# Altium schematic sheet LEDS_And_Buttons.SchDoc(LEDS_And_Buttons)
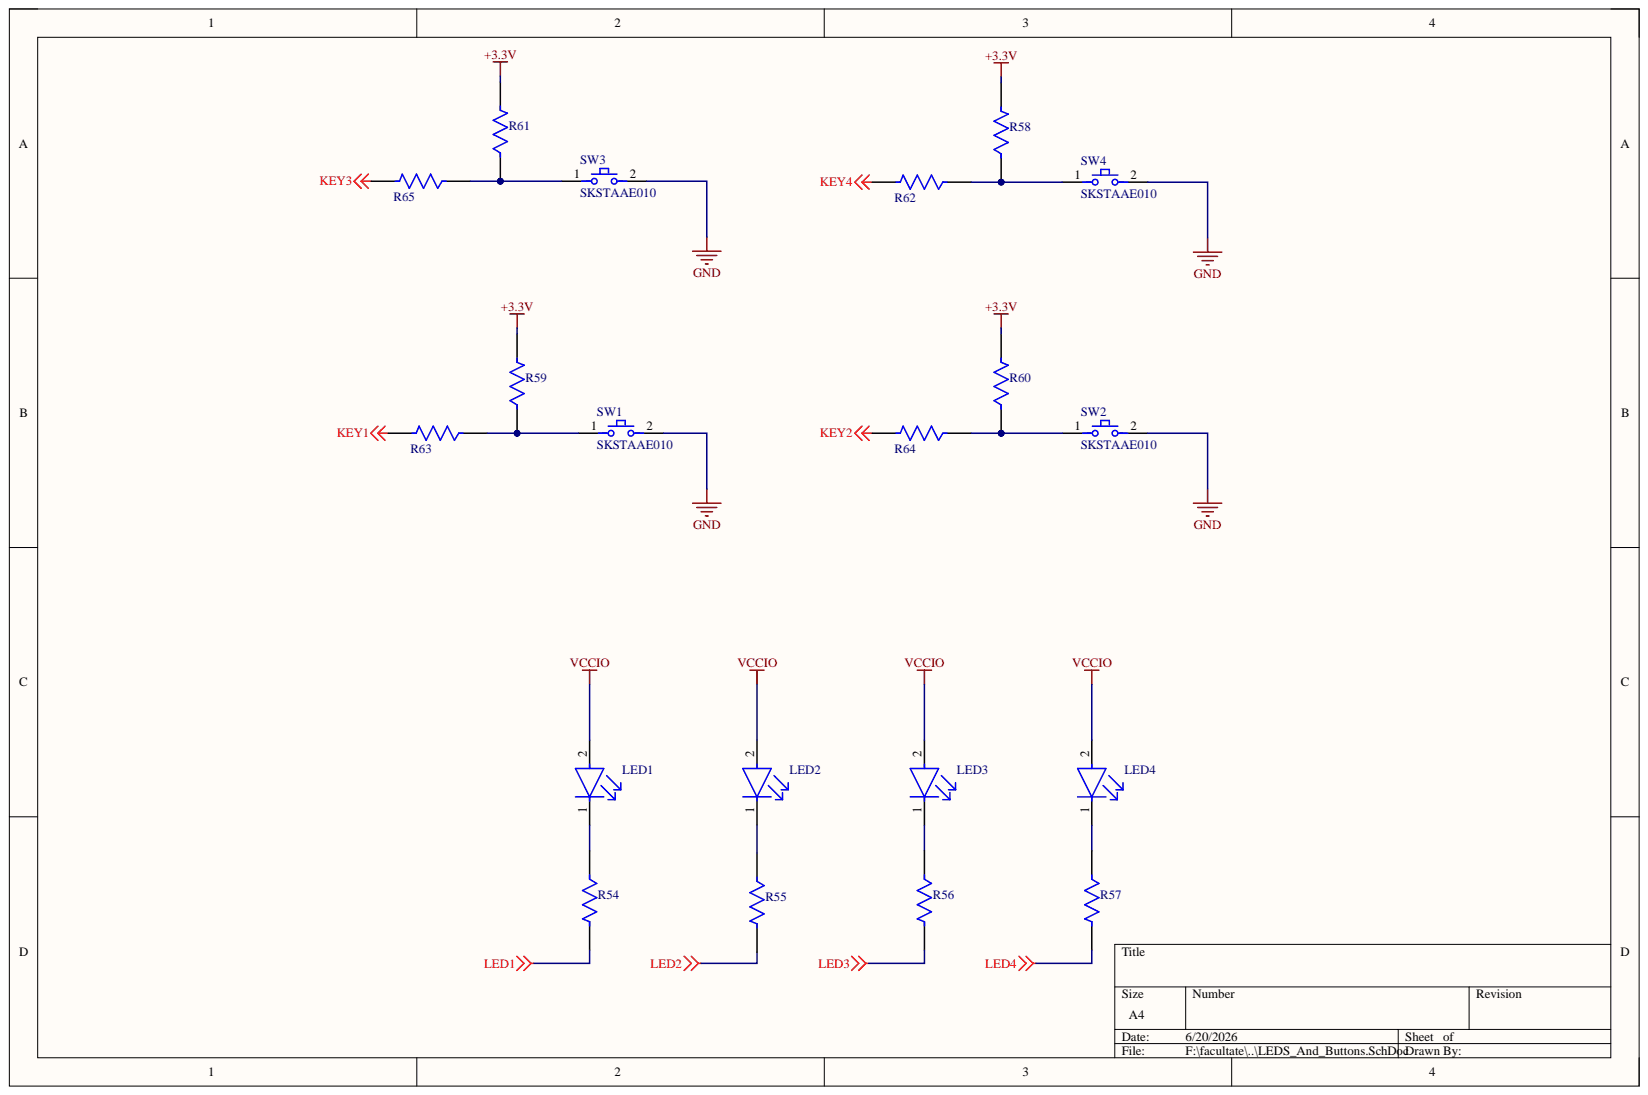
[diagram p0: LEDS_And_Buttons.SchDoc]

## Parts
LED1  LTST-C230EKT  [FP-LTST-C230EKT-MFG]  (value not drawn)
LED2  LTST-C230EKT  [FP-LTST-C230EKT-MFG]  (value not drawn)
LED3  LTST-C230EKT  [FP-LTST-C230EKT-MFG]  (value not drawn)
LED4  LTST-C230EKT  [FP-LTST-C230EKT-MFG]  (value not drawn)
R54  MCU0805MD4991BP100  [FP-MCU0805-MFG]  (value not drawn)
R55  MCU0805MD4991BP100  [FP-MCU0805-MFG]  (value not drawn)
R56  MCU0805MD4991BP100  [FP-MCU0805-MFG]  (value not drawn)
R57  MCU0805MD4991BP100  [FP-MCU0805-MFG]  (value not drawn)
R58  MCU0805MD4991BP100  [FP-MCU0805-MFG]  (value not drawn)
R59  MCU0805MD4991BP100  [FP-MCU0805-MFG]  (value not drawn)
R60  MCU0805MD4991BP100  [FP-MCU0805-MFG]  (value not drawn)
R61  MCU0805MD4991BP100  [FP-MCU0805-MFG]  (value not drawn)
R62  MCU0805MD4991BP100  [FP-MCU0805-MFG]  (value not drawn)
R63  MCU0805MD4991BP100  [FP-MCU0805-MFG]  (value not drawn)
R64  MCU0805MD4991BP100  [FP-MCU0805-MFG]  (value not drawn)
R65  MCU0805MD4991BP100  [FP-MCU0805-MFG]  (value not drawn)
SW1  SKSTAAE010  [FP-SKSTAAE010-MFG]
SW2  SKSTAAE010  [FP-SKSTAAE010-MFG]
SW3  SKSTAAE010  [FP-SKSTAAE010-MFG]
SW4  SKSTAAE010  [FP-SKSTAAE010-MFG]

## Nets
+3.3V: R58.2 R59.2 R60.2 R61.2
GND: SW1.2 SW2.2 SW3.2 SW4.2
KEY1: R63.2
KEY2: R64.2
KEY3: R65.2
KEY4: R62.2
LED1: R54.2
LED2: R55.2
LED3: R56.2
LED4: R57.2
VCCIO: LED1.2 LED2.2 LED3.2 LED4.2
NetLED1_1: LED1.1 R54.1
NetLED2_1: LED2.1 R55.1
NetLED3_1: LED3.1 R56.1
NetLED4_1: LED4.1 R57.1
NetR58_1: R58.1 R62.1 SW4.1
NetR59_1: R59.1 R63.1 SW1.1
NetR60_1: R60.1 R64.1 SW2.1
NetR61_1: R61.1 R65.1 SW3.1

## Library data of the parts
LED1-LED4 = CMP-22017-000030-1: LED RED CLEAR CHIP SMD; Dominant Wavelength: 621nm; Forward Current: 30mA; Forward Voltage: 2V; Lens Color: Colorless; Lens Style: Diffused; Luminous Intensity: 6mcd; Number of Elements: 1; Power Dissipation: 100mW; Reverse Voltage: 5V; Test Current: 10mA; Viewing Angle: 130°; Wavelength: 630nm; Case Code (Imperial): 1206; Case Code (Metric): 3216; Case/Package: 1206; Color: Red; Component Kind: Standard; Depth: 1.6mm; Height: 1.1mm; Illumination Color: Red; Lead Free: Lead Free; Length: 3.2mm; Lens Transparency: Clear; Library Name: Altium Content Vault; Max Operating Temperature: 85°C; Min Operating Temperature: -55°C; Mount: Surface Mount; Number of LEDs: 1; Number of Pins: 2; Package Quantity: 1; Packaging: Tape and Reel; Power Rating: 100mW; Radiation Hardening: No; Width: 1.6mm
R54-R65 = CMP-02407-000217-1: RES 1.05K OHM 0.5% 1/5W 0805; Power Rating: 200mW; Resistance: 4.99kR; Tolerance: 0.1%; Voltage Rating: 150V; Case Code (Imperial): 0805; Case Code (Metric): 2012; Case/Package: 0805; Component Kind: Standard; Composition: Thin Film; Height: 0.52mm; Length: 2mm; Library Name: Altium Content Vault; Max Operating Temperature: 155°C; Min Operating Temperature: -55°C; Package Quantity: 1000; Packaging: Tape and Reel; Termination: SMD/SMT; Weight: 0.000194oz; Width: 1.25mm
SW1-SW4 = CMP-24311-000002-1: Tactile Switches Quiet 0.9mm Middle Stroke, 4N,500KCycle; Component Kind: Standard; Lead Free: Lead Free; Library Name: Altium Content Vault
